# Altium schematic sheet schem_v0.SchDoc(schem_v0)
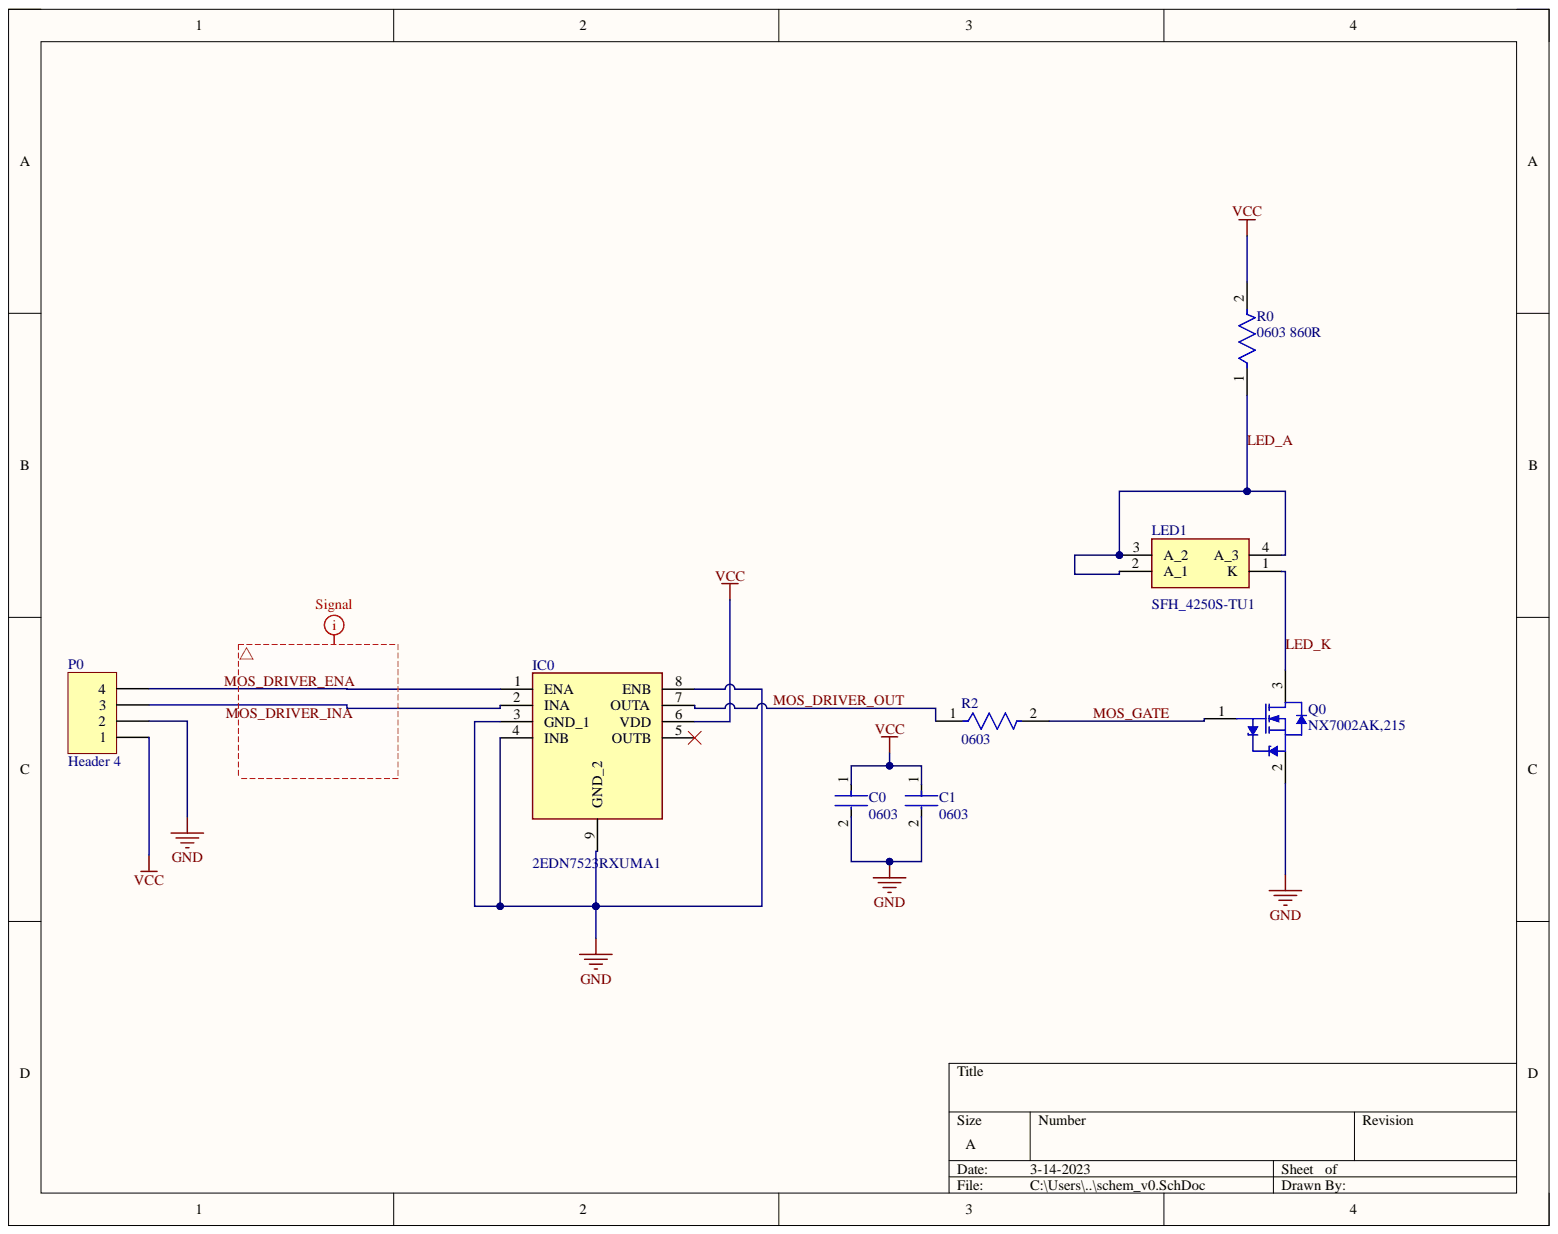
[diagram p0: schem_v0.SchDoc]

## Parts
C0  0603  [FP-GRM188-0_1-e0_2_0_55-IPC_A]
C1  0603  [FP-GRM188-0_1-e0_2_0_55-IPC_A]
IC0  2EDN7523RXUMA1  [SOP65P490X104-9N]
LED1  SFH_4250S-TU1  [SFH4250STU1]
P0  Header 4  [HDR1X4]
Q0  NX7002AK,215  [NXP-SOT23_L]
R0  0603 860R  Case Code (Imperial): 0603  [FP-ERA3A-IPC_C]
R2  0603  [FP-ERA3A-IPC_C]

## Nets
GND: C0.2 C1.2 IC0.3(GND_1) IC0.4(INB) IC0.8(ENB) IC0.9(GND_2) P0.2 Q0.2
VCC: C0.1 C1.1 IC0.6(VDD) P0.1 R0.2
LED_A: LED1.2(A_1) LED1.3(A_2) LED1.4(A_3) R0.1
LED_K: LED1.1(K) Q0.3
MOS_DRIVER_ENA: IC0.1(ENA) P0.4
MOS_DRIVER_INA: IC0.2(INA) P0.3
MOS_DRIVER_OUT: IC0.7(OUTA) R2.1
MOS_GATE: Q0.1 R2.2

Not connected: IC0 5(OUTB)

## Library data of the parts
C0 C1 = CMP-2006-02792-2: Chip Multilayer Ceramic Capacitors for General Purpose, 0603, 10uF, X5R, 15%, 20%, 6.3V; Capacitance: 10uF; Case Code (Imperial): 0603; Case Code (Metric): 1608; Case/Package: 0603; Component Kind: Standard; Depth: 0.8mm; Dielectric: X5R; Height: 0.8mm; Lead Free: Lead Free; Length: 1.6mm; Library Name: Altium Content Vault; Material: Ceramic; Max Operating Temperature: 85°C; Min Operating Temperature: -55°C; Mount: Surface Mount; Package Quantity: 4000; Packaging: Tape and Reel; Radiation Hardening: No; REACH SVHC: No SVHC; Termination: SMD/SMT; Thickness: 0.035inch; Tolerance: 20%; Voltage: 6.3V; Voltage Rating: 6.3V; Voltage Rating (DC): 6.3V; Width: 0.031inch
IC0 = 2EDN7523RXUMA1: Integrated Circuit; Manufacturer_Name: Infineon; Arrow Part Number: 2EDN7523RXUMA1; Arrow Price/Stock: https://www.arrow.com/en/products/2edn7523rxuma1/infineon-technologies-ag?region=nac; Component Kind: Standard; Datasheet Link: https://www.infineon.com/dgdl/Infineon-2EDN752x-2EDN852x-DS--DS-v02_05-EN.pdf?fileId=5546d462525dbac40152abcc7dbb1727; Height: 1.04mm; Manufacturer_Part_Number: 2EDN7523RXUMA1; Mouser Part Number: 726-2EDN7523RXUMA1; Mouser Price/Stock: https://www.mouser.co.uk/ProductDetail/Infineon-Technologies/2EDN7523RXUMA1?qs=QIiuLP2W41C%2FmI0Fq5IeEg%3D%3D
LED1 = SFH_4250S-TU1: LED; Manufacturer_Name: OSRAM; Manufacturer_Part_Number: SFH 4250S-TU1; Arrow Part Number: SFH 4250S-TU1; Arrow Price/Stock: https://www.arrow.com/en/products/sfh-4250s-tu1/osram-opto-semiconductors?region=europe; Component Kind: Standard; Datasheet Link: https://www.arrow.com/en/products/sfh-4250s-tu1/osram-opto-semiconductors; Height: 2.1mm; Mouser Part Number: 720-SFH4250SST; Mouser Price/Stock: https://www.mouser.co.uk/ProductDetail/OSRAM-Opto-Semiconductors/SFH-4250S-TU1/?qs=%252BEew9%252B0nqrBKXxkCaFvm1A%3D%3D
P0 = Header 4: Header, 4-Pin; Component Kind: Standard; LatestRevisionDate: 17-Jul-2002; LatestRevisionNote: Re-released for DXP Platform.; Library Name: Miscellaneous Connectors.IntLib; Signal Integrity: Connector; Publisher: Altium Limited
Q0 = CMP-1258-00137-1: Single N-Channel Trench MOSFET, 60 V, -55 to 150 degC, 3-Pin SOT23, Tape and Reel; Manufacturer: NXP Semiconductors; Channel Type: N; Ciss [typ] (pF): 11; Coss [typ] (pF): 3.4; Packing: Tape & Reel; VDS [max] (V): 60; VGSth [typ] (V): 1.6; Code_JEDEC: TO-236AB; Component Kind: Standard; ComponentLink1Description: Manufacturer URL; ComponentLink1URL: http://www.nxp.com/; ComponentLink2Description: Datasheet; ComponentLink2URL: http://www.nxp.com/documents/data_sheet/NX7002AK.pdf; ComponentLink3Description: Package Specification; ComponentLink3URL: http://www.nxp.com/documents/outline_drawing/sot023_po.pdf; DatasheetVersion: Rev. 5; Library Name: Altium Content Vault; Mounting Technology: Surface Mount; PackageDescription: 3-Pin Small Outline Transistor Package, Body 2.9 x 1.3 mm, Pitch 0.95 mm; PackageReference: SOT23; PackageVersion: 06-03-16, 03/2006; PartNumber: NX7002AK,215
R0 = CMP-2000-00873-2: RES SMD 1K OHM 0.1% 1/10W 0603; Max Power Dissipation: 100mW; Temperature Coefficient: 25ppm/°C; Voltage Rating: 75V; Case Code (Metric): 1608; Case/Package: 0603; Component Kind: Standard; Construction: Rectangular; Contact Plating: Tin; Depth: 0.8mm; Height: 0.45mm; Length: 1.6mm; Library Name: Altium Content Vault; Max Operating Temperature: 155°C; Military Standard: Not; Min Operating Temperature: -55°C; Mount: Surface Mount; Number of Pins: 2; Number of Terminations: 2; Package Quantity: 5000; Packaging: Tape and Reel; Power Rating: 100mW; Radiation Hardening: No; REACH SVHC: No SVHC; Resistance: 860R; Resistance Tolerance: 0.1%; Resistor Type: Thin Film; RoHS Compliant: Yes; Series: ERA-3A; Size Code: 0603; Technology: METAL FILM; Termination: SMD/SMT; Tolerance: 0.1%; Width: 0.8mm
R2 = CMP-2000-00873-2: RES SMD 1K OHM 0.1% 1/10W 0603; Max Power Dissipation: 100mW; Resistance: 1kR; Temperature Coefficient: 25ppm/°C; Voltage Rating: 75V; Case Code (Imperial): 0603; Case Code (Metric): 1608; Case/Package: 0603; Component Kind: Standard; Construction: Rectangular; Contact Plating: Tin; Depth: 0.8mm; Height: 0.45mm; Length: 1.6mm; Library Name: Altium Content Vault; Max Operating Temperature: 155°C; Military Standard: Not; Min Operating Temperature: -55°C; Mount: Surface Mount; Number of Pins: 2; Number of Terminations: 2; Package Quantity: 5000; Packaging: Tape and Reel; Power Rating: 100mW; Radiation Hardening: No; REACH SVHC: No SVHC; Resistance Tolerance: 0.1%; Resistor Type: Thin Film; RoHS Compliant: Yes; Series: ERA-3A; Size Code: 0603; Technology: METAL FILM; Termination: SMD/SMT; Tolerance: 0.1%; Width: 0.8mm
